# Altium schematic sheet SmartLED.SchDoc(SL)
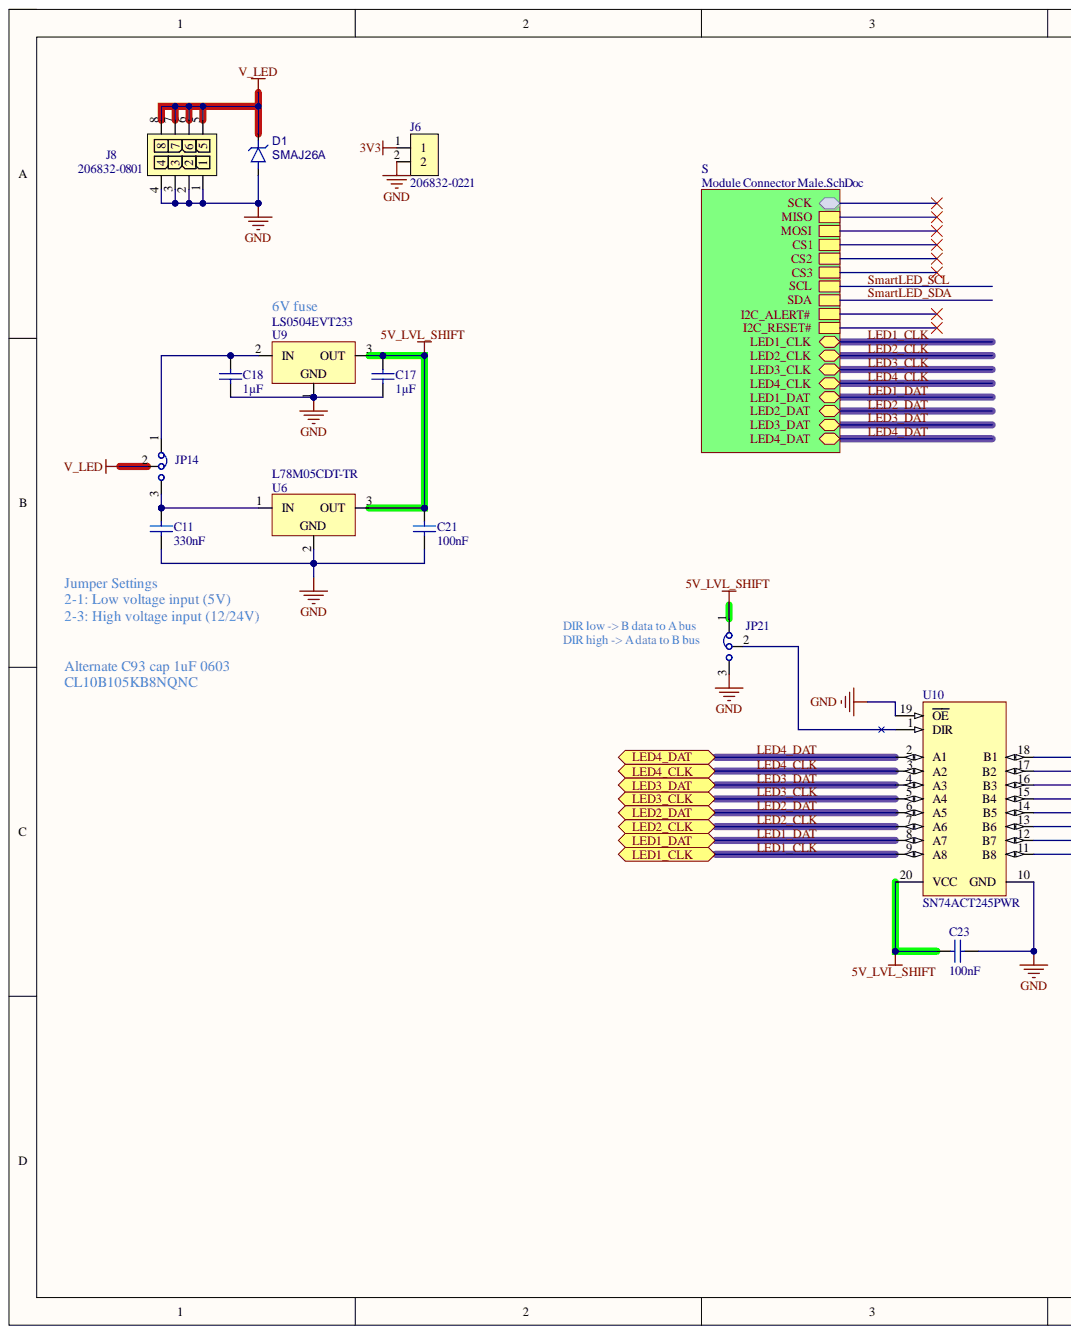
[diagram p0: SmartLED.SchDoc, left]
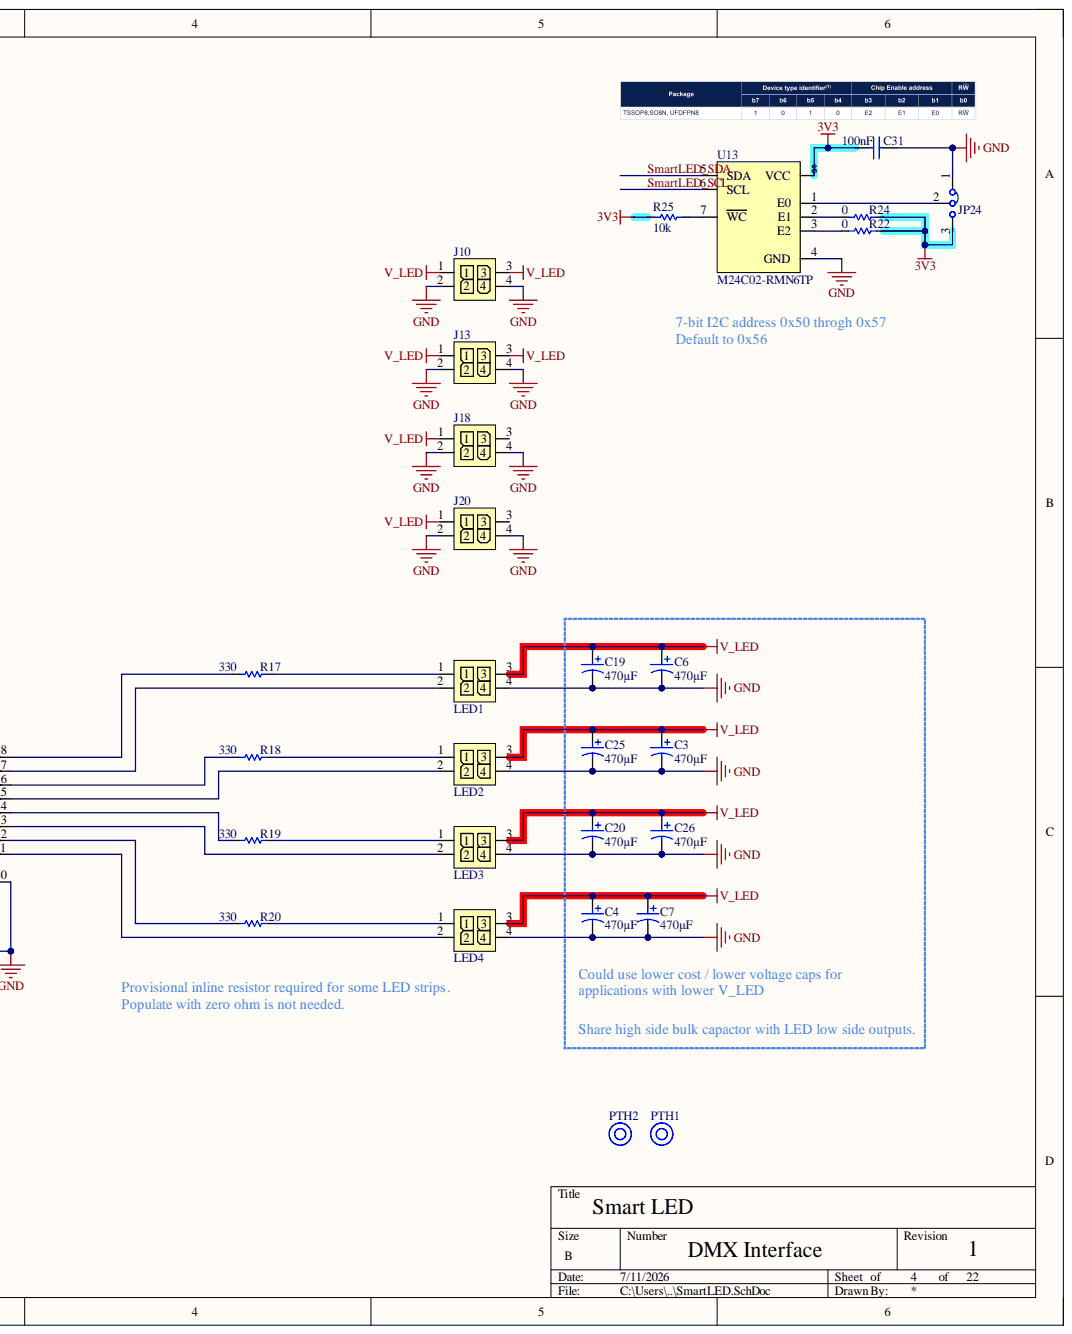
[diagram p1: SmartLED.SchDoc, right]

## Parts
C3  value 470µF  [CAP_POL_DIAM10_H22_SPACING5]  (hidden comment: REH1020471M050K)
C4  value 470µF  [CAP_POL_DIAM10_H22_SPACING5]  (hidden comment: REH1020471M050K)
C6  value 470µF  [CAP_POL_DIAM10_H22_SPACING5]  (hidden comment: REH1020471M050K)
C7  value 470µF  [CAP_POL_DIAM10_H22_SPACING5]  (hidden comment: REH1020471M050K)
C11  value 330nF  [CAPC1608X10N_0603_MED]  (hidden comment: CGA3E3X7R1H334K080AB)
C17  value 1µF  [CAPC1608X10N_0603_MED]  (hidden comment: C1608X7R1C105K080AC)
C18  value 1µF  [CAPC2012X14N_0805_MED]  (hidden comment: CL21B105KBFNNNE)
C19  value 470µF  [CAP_POL_DIAM10_H22_SPACING5]  (hidden comment: REH1020471M050K)
C20  value 470µF  [CAP_POL_DIAM10_H22_SPACING5]  (hidden comment: REH1020471M050K)
C21  value 100nF  [CAPC1608X10N_0603_MED]  (hidden comment: CC0603KRX7R0BB104)
C23  value 100nF  [CAPC1608X10N_0603_MED]  (hidden comment: CC0603KRX7R0BB104)
C25  value 470µF  [CAP_POL_DIAM10_H22_SPACING5]  (hidden comment: REH1020471M050K)
C26  value 470µF  [CAP_POL_DIAM10_H22_SPACING5]  (hidden comment: REH1020471M050K)
C31  value 100nF  [CAPC1608X10N_0603_MED]  (hidden comment: CC0603KRX7R0BB104)
D1  SMAJ26A  [FP-SMAJ-MFG]
J6  206832-0221  [206832-0221-Footprint-1]
J8  206832-0801  [206832-0801-Footprint-1]
J10  212528-0430  [212528-0430-Footprint-1]  (value not drawn)
J13  212528-0430  [212528-0430-Footprint-1]  (value not drawn)
J18  212528-0430  [212528-0430-Footprint-1]  (value not drawn)
J20  212528-0430  [212528-0430-Footprint-1]  (value not drawn)
JP14  Jumper 3 x 1 Bridge 1-2 0.1"  [FP-PR20203VBNN-MFG]  (value not drawn)
JP21  Jumper 3 x 1 Bridge 1-2 Solder Bridge  [Jumper 3 Solder Bridge 1-2 0603]  (value not drawn)
JP24  Jumper 3 x 1 Bridge 1-2 Solder Bridge  [Jumper 3 Solder Bridge 1-2 0603]  (value not drawn)
LED1  212528-0400  [212528-0400-Footprint-1]  (value not drawn)
LED2  212528-0400  [212528-0400-Footprint-1]  (value not drawn)
LED3  212528-0400  [212528-0400-Footprint-1]  (value not drawn)
LED4  212528-0400  [212528-0400-Footprint-1]  (value not drawn)
PTH1  Mounting Hole NPTH  [Mounting Hole M3 No Washer NPTH]  (value not drawn)  (no BOM)
PTH2  Mounting Hole NPTH  [Mounting Hole M3 No Washer NPTH]  (value not drawn)  (no BOM)
R17  value 330  [RESC1608X06N_0603_MED]  (hidden comment: RC0603FR-07330RL)
R18  value 330  [RESC1608X06N_0603_MED]  (hidden comment: RC0603FR-07330RL)
R19  value 330  [RESC1608X06N_0603_MED]  (hidden comment: RC0603FR-07330RL)
R20  value 330  [RESC1608X06N_0603_MED]  (hidden comment: RC0603FR-07330RL)
R22  value 0  [RESC1608X06N_0603_MED]  (hidden comment: RC0603FR-070RL)
R24  value 0  [RESC1608X06N_0603_MED]  (hidden comment: RC0603FR-070RL)
R25  value 10k  [RESC1608X06N_0603_MED]  (hidden comment: RC0603FR-1010KL)
U6  L78M05CDT-TR  [L78M05CDT-TR-Footprint-1]
U9  LS0504EVT233  [SOT95P280X100-3N]
U10  SN74ACT245PWR  [PW0020A_L]
U13  M24C02-RMN6TP  [M24C02-RMN6TP-SO8N-IPC_B]

## Ports
LED1_CLK, LED1_DAT, LED2_CLK, LED2_DAT, LED3_CLK, LED3_DAT, LED4_CLK, LED4_DAT

## Nets
3V3: C31.1 J6.1 JP24.3 R22.1 R24.1 R25.2 U13.8(VCC)
5V_LVL_SHIFT: C17.2 C21.1 C23.2 JP21.1 U6.3 U9.3 U10.20(VCC)
GND: C3.2 C4.2 C6.2 C7.2 C11.1 C17.1 C18.1 C19.2 C20.2 C21.2 C23.1 C25.2 C26.2 C31.2 D1.2 J6.2 J8.1 J8.2 J8.3 J8.4 J10.2 J10.4 J13.2 J13.4 J18.2 J18.4 J20.2 J20.4 JP21.3 JP24.1 LED1.4 LED2.4 LED3.4 LED4.4 U6.2 U9.1 U10.10(GND) U10.19(OE) U13.4(GND)
V_LED: C3.1 C4.1 C6.1 C7.1 C19.1 C20.1 C25.1 C26.1 D1.1 J8.5 J8.6 J8.7 J8.8 J10.1 J10.3 J13.1 J13.3 J18.1 J20.1 JP14.2 LED1.3 LED2.3 LED3.3 LED4.3
LED1_CLK: U10.9(A8) ; port LED1_CLK
LED1_DAT: U10.8(A7) ; port LED1_DAT
LED2_CLK: U10.7(A6) ; port LED2_CLK
LED2_DAT: U10.6(A5) ; port LED2_DAT
LED3_CLK: U10.5(A4) ; port LED3_CLK
LED3_DAT: U10.4(A3) ; port LED3_DAT
LED4_CLK: U10.3(A2) ; port LED4_CLK
LED4_DAT: U10.2(A1) ; port LED4_DAT
SmartLED_SCL: U13.6(SCL)
SmartLED_SDA: U13.5(SDA)
NetC11_2: C11.2 JP14.3 U6.1
NetC18_2: C18.2 JP14.1 U9.2
NetJ18_3: J18.3
NetJ20_3: J20.3
NetJP21_2: JP21.2 U10.1(DIR)
NetJP24_2: JP24.2 U13.1(E0)
NetLED1_1: LED1.1 R17.2
NetLED1_2: LED1.2 U10.17(B2)
NetLED2_1: LED2.1 R18.2
NetLED2_2: LED2.2 U10.15(B4)
NetLED3_1: LED3.1 R19.2
NetLED3_2: LED3.2 U10.13(B6)
NetLED4_1: LED4.1 R20.2
NetLED4_2: LED4.2 U10.11(B8)
NetR17_1: R17.1 U10.18(B1)
NetR18_1: R18.1 U10.16(B3)
NetR19_1: R19.1 U10.14(B5)
NetR20_1: R20.1 U10.12(B7)
NetR22_2: R22.2 U13.3(E2)
NetR24_2: R24.2 U13.2(E1)
NetR25_1: R25.1 U13.7(WC)
CS1:
CS2:
CS3:
I2C_ALERT#:
I2C_RESET#:
MISO:
MOSI:
SCK:

## Library data of the parts
C3 C4 C6 C7 C19 C20 C25 C26 = CMP-001-00166-1: CAP ALUM 470UF 20% 50V RADIAL TH; Capacitor Type: Aluminium; Case/Package: Radial; Component Kind: Standard; Max Operating Temperature: -40°C; Min Operating Temperature: 125°C; Mounting Technology: Through Hole; Pins: 2; RoHS Compliant: Yes; Tolerance: 20%; Voltage Rating: 50V; Library Name: Equus Computer Systems
C11 = CMP-001-00167-2: Ceramic Capacitor, Multilayer, Ceramic, 50V, 10% +Tol, 10% -Tol, X7R, 15% TC, 0.33uF, Surface Mount, 0603; Voltage Rating: 100V; Capacitor Type: Ceramic; Case/Package: 0603; Component Kind: Standard; JLCPN: C5199872; Max Operating Temperature: 125°C; Min Operating Temperature: -55°C; Mounting Technology: Surface Mount; Pins: 2; RoHS Compliant: Yes; Library Name: Equus Computer Systems
C17 = CMP-001-00121-2: Ceramic Capacitor, Multilayer, Ceramic, 16V, 10% +Tol, 10% -Tol, X7R, 15% TC, 1uF, Surface Mount, 0603; Capacitor Type: Ceramic; Case/Package: 0603; Component Kind: Standard; JLCPN: C59782; Max Operating Temperature: 125°C; Min Operating Temperature: -55°C; Mounting Technology: Surface Mount; Pins: 2; RoHS Compliant: Yes; Tolerance: 10%; Voltage Rating: 16V; Library Name: Equus Computer Systems
C18 = CMP-001-00171-2: Ceramic Capacitor, Multilayer, Ceramic, 1uF, 50V, 10%, XRS, 0805; Capacitor Type: Ceramic; Case/Package: 0805; Component Kind: Standard; JLCPN: C28323; Max Operating Temperature: 125°C; Min Operating Temperature: -55°C; Mounting Technology: Surface Mount; Pins: 2; RoHS Compliant: Yes; Tolerance: 10%; Voltage Rating: 50V; Library Name: Equus Computer Systems
C21 C23 C31 = CMP-001-00102-4: Ceramic Capacitor, Multilayer, Ceramic, 100V, 10% +Tol, 10% -Tol, X7R, 15% TC, 0.1uF, Surface Mount, 0603; Capacitor Type: Ceramic; Case/Package: 0603; Component Kind: Standard; JLCPN: C113803; Max Operating Temperature: 125°C; Min Operating Temperature: -55°C; Mounting Technology: Surface Mount; Pins: 2; RoHS Compliant: Yes; Voltage Rating: 100V; Library Name: Equus Computer Systems
D1 = CMP-004-00046-2: Trans Voltage Suppressor Diode, 400W, 26V V(RWM), Unidirectional, 1 Element, Silicon, DO-214AC; Component Kind: Standard; JLCPN: C148225; Max Operating Temperature: 150°C; Min Operating Temperature: -65°C; Pins: 2; RoHS Compliant: Yes; Library Name: Equus Computer Systems
J6 = CMP-002-00210-4: Micro-Fit+, Vertial Header, 2 Position 3mm pitch; Gender: Male; Max Current Rating: 12.5A; Component Kind: Standard; Connector Type: Micro-Fit+; Pins: 2; Library Name: Equus Computer Systems
J8 = CMP-002-00208-6: Micro-Fit+, Vertical Header, 8 pin; Max Current Rating: 12.5A; Component Kind: Standard; Connector Type: Micro-Fit+; Mounting Technology: Through Hole; Pins: 8; Library Name: Equus Computer Systems
J10 J13 J18 J20 = CMP-002-00220-4: Micro-Fit+, RA Header, 4 Position, Blue D-Key; Gender: Male; Max Current Rating: 12.5A; Component Kind: Standard; Connector Type: Micro-Fit+; Max Operating Temperature: 125°C; Min Operating Temperature: -40°C; Mounting Technology: Through Hole; Pins: 4; Library Name: Equus Computer Systems
JP14 = CMP-002-00084-6: Component Kind: Standard; Description: Jumper 3 x 1 Bridge 1-2 0.1"; Pins: 3; Library Name: Equus Computer Systems
JP21 JP24 = CMP-009-00341-2: 0603 Solder Bridge Jumper Surface Mount; Tolerance: 1%; Value: 0; Voltage Rating: 75V; Case/Package: 0603; Component Kind: Standard; Max Operating Temperature: 155°C; Min Operating Temperature: -55°C; Mounting Technology: Surface Mount; Pins: 2; RoHS Compliant: Yes; Library Name: Equus Computer Systems
LED1-LED4 = CMP-002-00219-3: Micro-Fit+, RA Header, 4 Position, Black A-Key; Gender: Male; Max Current Rating: 12.5A; Component Kind: Standard; Connector Type: Micro-Fit+; Mounting Technology: Through Hole; Pins: 4; Library Name: Equus Computer Systems
PTH1 PTH2 = CMP-00028-00043-3: Description: Mounting Hole NPTH; Library Name: Equus Computer Systems
R17-R20 = CMP-009-00302-2: Fixed Resistor, Metal Glaze/thick Film, 0.1W, 330ohm, 75V, 1% +/-Tol, 100ppm/Cel, Surface Mount, 0603; Power: 100mW; Voltage Rating: 50V; Case/Package: 0603; Component Kind: Standard; JLCPN: C105881; Max Operating Temperature: 155°C; Min Operating Temperature: -55°C; Mounting Technology: Surface Mount; Pins: 2; RoHS Compliant: Yes; Tolerance: 1%; Library Name: Equus Computer Systems
R22 R24 = CMP-009-00204-3: RC Series 0603 0.1 W 0 Ohm Jumper Surface Mount Thick Film Chip Resistor; Power: 100mW; Tolerance: 1%; Voltage Rating: 75V; Case/Package: 0603; Component Kind: Standard; JLCPN: C100044; Max Operating Temperature: 155°C; Min Operating Temperature: -55°C; Mounting Technology: Surface Mount; Pins: 2; RoHS Compliant: Yes; Library Name: Equus Computer Systems
R25 = CMP-009-00306-2: Fixed Resistor, Metal Glaze/thick Film, 0.1W, 10000ohm, 75V, 1% +/-Tol, 100ppm/Cel, Surface Mount, 0603; Power: 100mW; Voltage Rating: 50V; Case/Package: 0603; Component Kind: Standard; JLCPN: C98220; Max Operating Temperature: 155°C; Min Operating Temperature: -55°C; Mounting Technology: Surface Mount; Pins: 2; RoHS Compliant: Yes; Tolerance: 1%; Library Name: Equus Computer Systems
U6 = CMP-019-00076-2: Fixed Positive Standard Regulator, 5VBIPolar, PSSO2; Max Supply Voltage: 25V; Output Current: 500mA; Output Voltage: 5V; Case/Package: TO-252; Component Kind: Standard; JLCPN: C55509; Pins: 2; RoHS Compliant: Yes; Library Name: Equus Computer Systems
U9 = CMP-005-00015-4: E-Fuse, LS0504 Series Single Channel 4 A High Side SMT Power Switch - SOT-23-3; Current Rating: 4A; Case/Package: SOT-23; Component Kind: Standard; Pins: 3; Library Name: Equus Computer Systems
U10 = CMP-016-00027-3: Bus Transceiver, ACT Series, 1-Func, 8-Bit, True Output, CMOS, PDSO20; Max Supply Voltage: 5.5V; Min Supply Voltage: 4.5V; Nominal Supply Voltage: 5V; Case/Package: TSSOP; Component Kind: Standard; JLCPN: C6931; Logic Type: BUS TRANSCEIVER; Max Operating Temperature: 85°C; Min Operating Temperature: -40°C; Pins: 20; RoHS Compliant: Yes; Library Name: Equus Computer Systems
U13 = CMP-019-00078-2: M24C02 Series 2 Kb (256 x 8) 1.8 V SMT Serial I²C Bus EEPROM - SOIC-8; Clock Frequency: 400kHz; Max Supply Voltage: 5.5V; Memory Size: 256B; Min Supply Voltage: 1.8V; Case/Package: SOIC; Component Kind: Standard; Frequency: 400kHz; JLCPN: C83836; Max Operating Temperature: 85°C; Memory Format: EEPROM; Min Operating Temperature: -40°C; Mounting Technology: Surface Mount; Pins: 8; Library Name: Equus Computer Systems
